# Altium schematic sheet INTERFACE_rev2.SchDoc(INTERFACE_rev2)
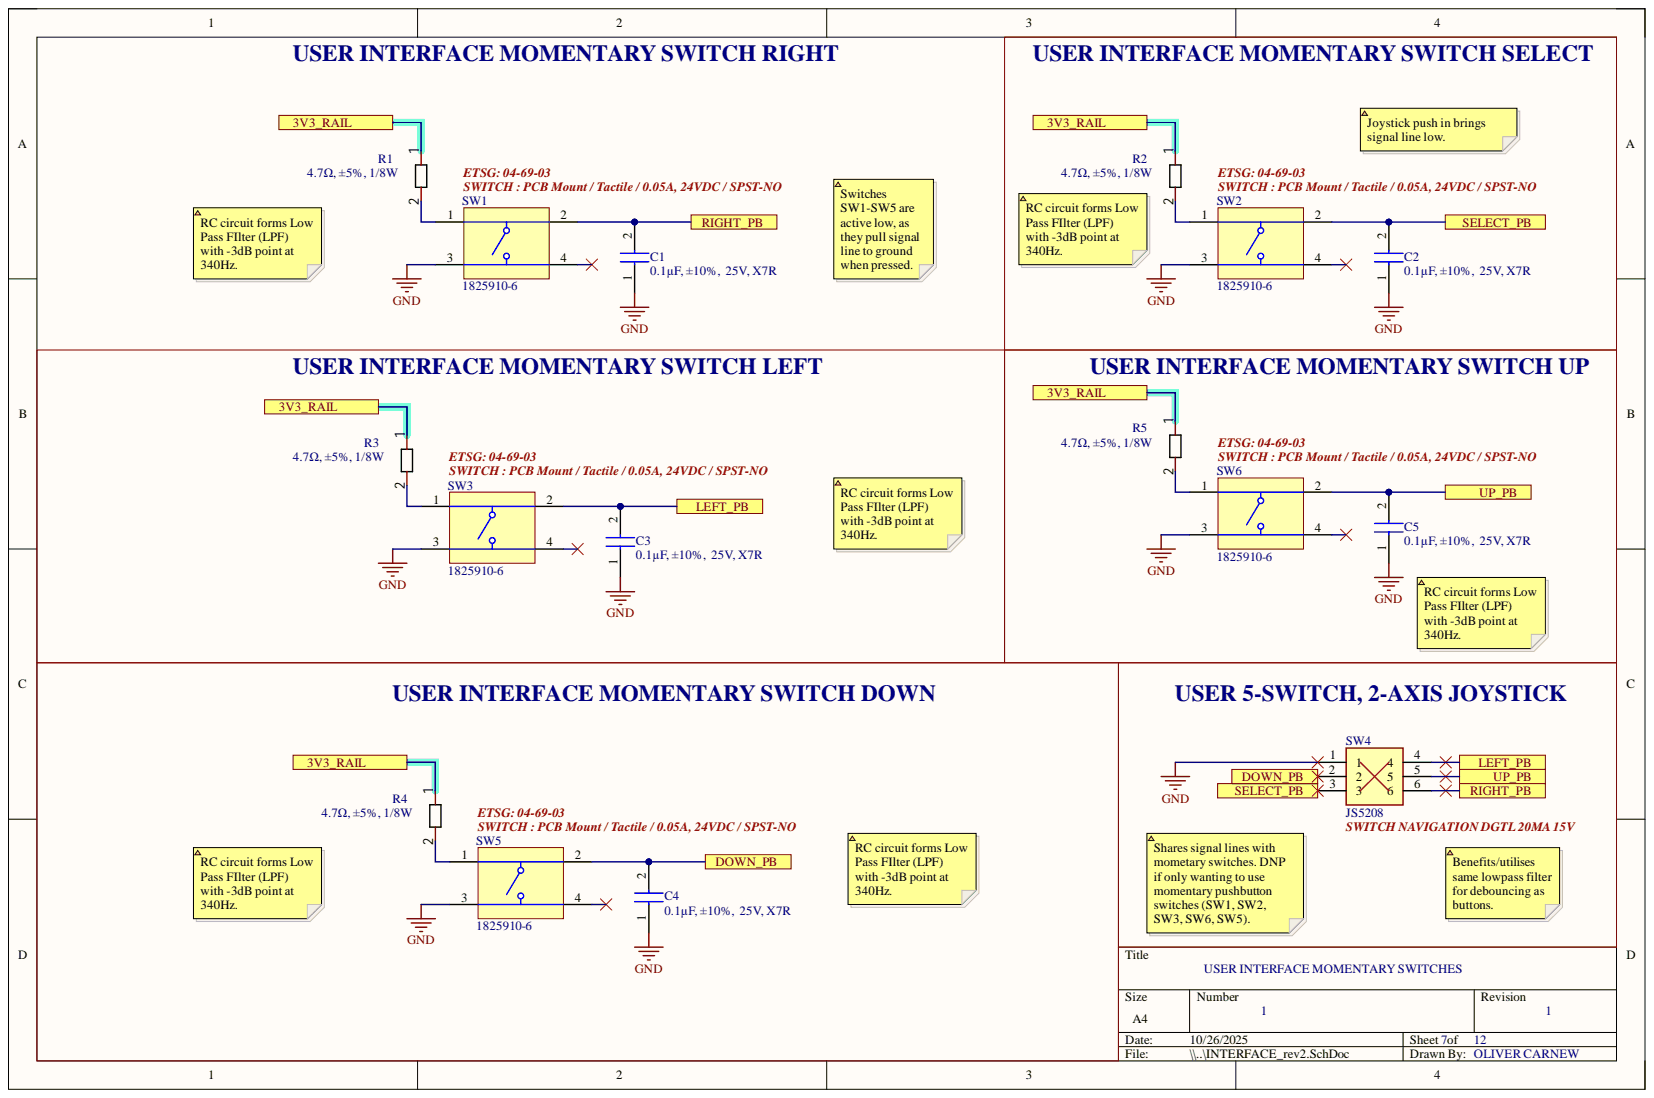
[diagram p0: INTERFACE_rev2.SchDoc]

## Parts
*  NC  (value not drawn)
C1  0.1µF, ±10%,  25V, X7R  [CAPC1005X55N]
C2  0.1µF, ±10%,  25V, X7R  [CAPC1005X55N]
C3  0.1µF, ±10%,  25V, X7R  [CAPC1005X55N]
C4  0.1µF, ±10%,  25V, X7R  [CAPC1005X55N]
C5  0.1µF, ±10%,  25V, X7R  [CAPC1005X55N]
R1  4.7Ω, ±5%, 1/8W  [SURFM RESISTOR]
R2  4.7Ω, ±5%, 1/8W  [SURFM RESISTOR]
R3  4.7Ω, ±5%, 1/8W  [SURFM RESISTOR]
R4  4.7Ω, ±5%, 1/8W  [SURFM RESISTOR]
R5  4.7Ω, ±5%, 1/8W  [SURFM RESISTOR]
SW1  1825910-6  [TECO-1825910-6_V]
SW2  1825910-6  [TECO-1825910-6_V]
SW3  1825910-6  [TECO-1825910-6_V]
SW4  JS5208  [JS5208]
SW5  1825910-6  [TECO-1825910-6_V]
SW6  1825910-6  [TECO-1825910-6_V]

## Ports
3V3_RAIL, DOWN_PB, LEFT_PB, RIGHT_PB, SELECT_PB, UP_PB

## Nets
GND: C1.1 C2.1 C3.1 C4.1 C5.1 SW1.3 SW2.3 SW3.3 SW4.1 SW5.3 SW6.3
3V3_RAIL: R1.1 R2.1 R3.1 R4.1 R5.1 ; port 3V3_RAIL
DOWN_PB: C4.2 SW4.2 SW5.2 ; port DOWN_PB
LEFT_PB: C3.2 SW3.2 SW4.4 ; port LEFT_PB
RIGHT_PB: C1.2 SW1.2 SW4.6 ; port RIGHT_PB
SELECT_PB: C2.2 SW2.2 SW4.3 ; port SELECT_PB
UP_PB: C5.2 SW4.5 SW6.2 ; port UP_PB
NetR1_2: R1.2 SW1.1
NetR2_2: R2.2 SW2.1
NetR3_2: R3.2 SW3.1
NetR4_2: R4.2 SW5.1
NetR5_2: R5.2 SW6.1

Not connected: SW1 4; SW2 4; SW3 4; SW5 4; SW6 4

## Library data of the parts
C1-C5 = CL05B104KA5NNNC: Capacitor CAP CER 0.1UF 25V X7R 0402 CL05B104KA5NNNC; Manufacturer_Name: SAMSUNG; Arrow Part Number: CL05B104KA5NNNC; Arrow Price/Stock: https://www.arrow.com/en/products/cl05b104ka5nnnc/samsung-electro-mechanics?region=nac; Component Kind: Standard; Datasheet Link: https://datasheet.datasheetarchive.com/originals/distributors/DKDS-19/372989.pdf; Height: 0.55mm; Manufacturer_Part_Number: CL05B104KA5NNNC; Mouser Part Number: 187-CL05B104KA5NNNC; Mouser Price/Stock: https://www.mouser.co.uk/ProductDetail/Samsung-Electro-Mechanics/CL05B104KA5NNNC?qs=Efv9o2T6U88DLyyMSzjXSQ%3D%3D; Parameter 1: Capacitor CAP CER 0.1UF 25V X7R 0402 CL05B104KA5NNNC
R1-R5 = 0805-R: Chip Resistor, 4.7 KOhm, +/- 1%, 0.1 W, -55 to 155 degC, 0603 (1608 Metric), RoHS, Tape and Reel RC0603FR-074K7L; Component Kind: Standard; Library Name: Control_unit.SchLib
SW1-SW3 SW5 SW6 = CMP-1684-00021-1: Tact Switch, SPST-NO, 0.05 A, -35 to 85 degC, 4-Pin THD, RoHS, Bulk; Manufacturer: TE Connectivity; Actuator Color: Black; Actuator Length: 1.4mm; Actuator Material: PolybutyleneTerephthalate; Actuator Style: RoundButton; Body Orientation: Straight; Bushing Type: Unthreaded; Contact Rating @ Voltage: 0.05A@24VDC; Dielectric Strength: 500VAC; Electrical Life: 100000Cycles; Function: MomentaryContact; Illumination: N/R; Maximum Contact Resistance: 100mOhm; Maximum DC Voltage Rating: 24V; Pole Throw Configuration: SPST; Switch Configuration: OFF(ON); Base Material: N/A; Component Kind: Standard; Contact Material: CopperAlloy; Contact Plating: Silver; Datasheet URL: http://www.te.com/commerce/DocumentDelivery/DDEController?Action=showdoc&DocId=Customer+Drawing%7F1825910%7FC9%7Fpdf%7FEnglish%7FENG_CD_1825910_C9.pdf%7F1825910-6; Datasheet Version: Rev. C9; Dimension: 5.99x5mm; Family: 1825910; Housing Material: N/A; Library Name: Altium Content Vault; Manufacturer URL: http://www.te.com; Maximum AC Voltage Rating: N/A; Mechanical Life: 100000Cycles; Minimum Insulation Resistance: 100MOhm; Mounting: ThroughHole; Operating Temperature: -35to85C; Package Description: 4-Pin Through Hole Device, Body 5.99 x 5.99 mm, Pitch 6.5 mm; Package Reference: THD; Packaging: N/A; Part No: 1825910-6; Product Weight: N/A; RoHS: Yes; Seal Description: N/A; Seal Location: N/A; Terminal Type: PCPins; Thread Size: N/R
SW4 = JS5208: Switch; Manufacturer_Name: E-Switch; Component Kind: Standard; Datasheet Link: https://configured-product-images.s3.amazonaws.com/2D/specs/JS5208.pdf; Height: 12.2mm; Library Name: SamacSys.SchLib; Manufacturer_Part_Number: JS5208; Mouser Part Number: 612-JS5208; Mouser Price/Stock: https://www.mouser.co.uk/ProductDetail/E-Switch/JS5208?qs=f57gQzlyLiqOzqNCAUlPQg%3D%3D
* = NC: Component Kind: Standard; Library Name: DANCE_LIB_REV2.SchLib
